# Altium schematic sheet 01.SchDoc
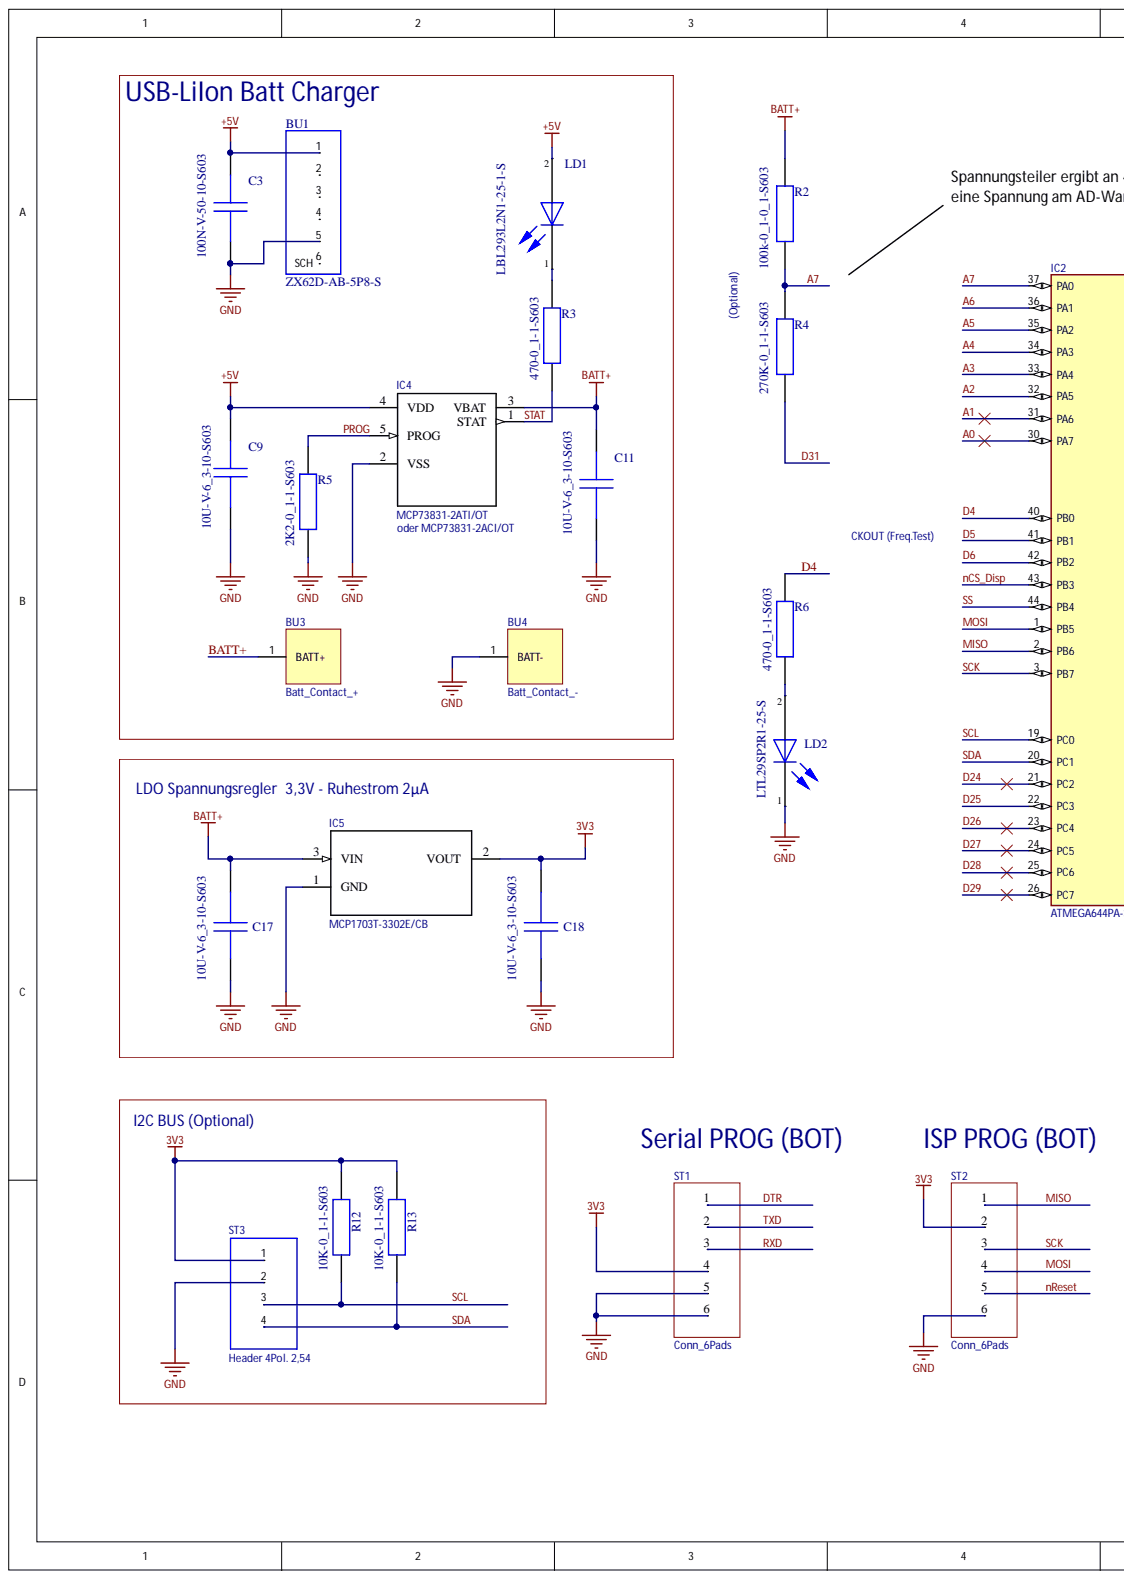
[diagram p0: 01.SchDoc, left]
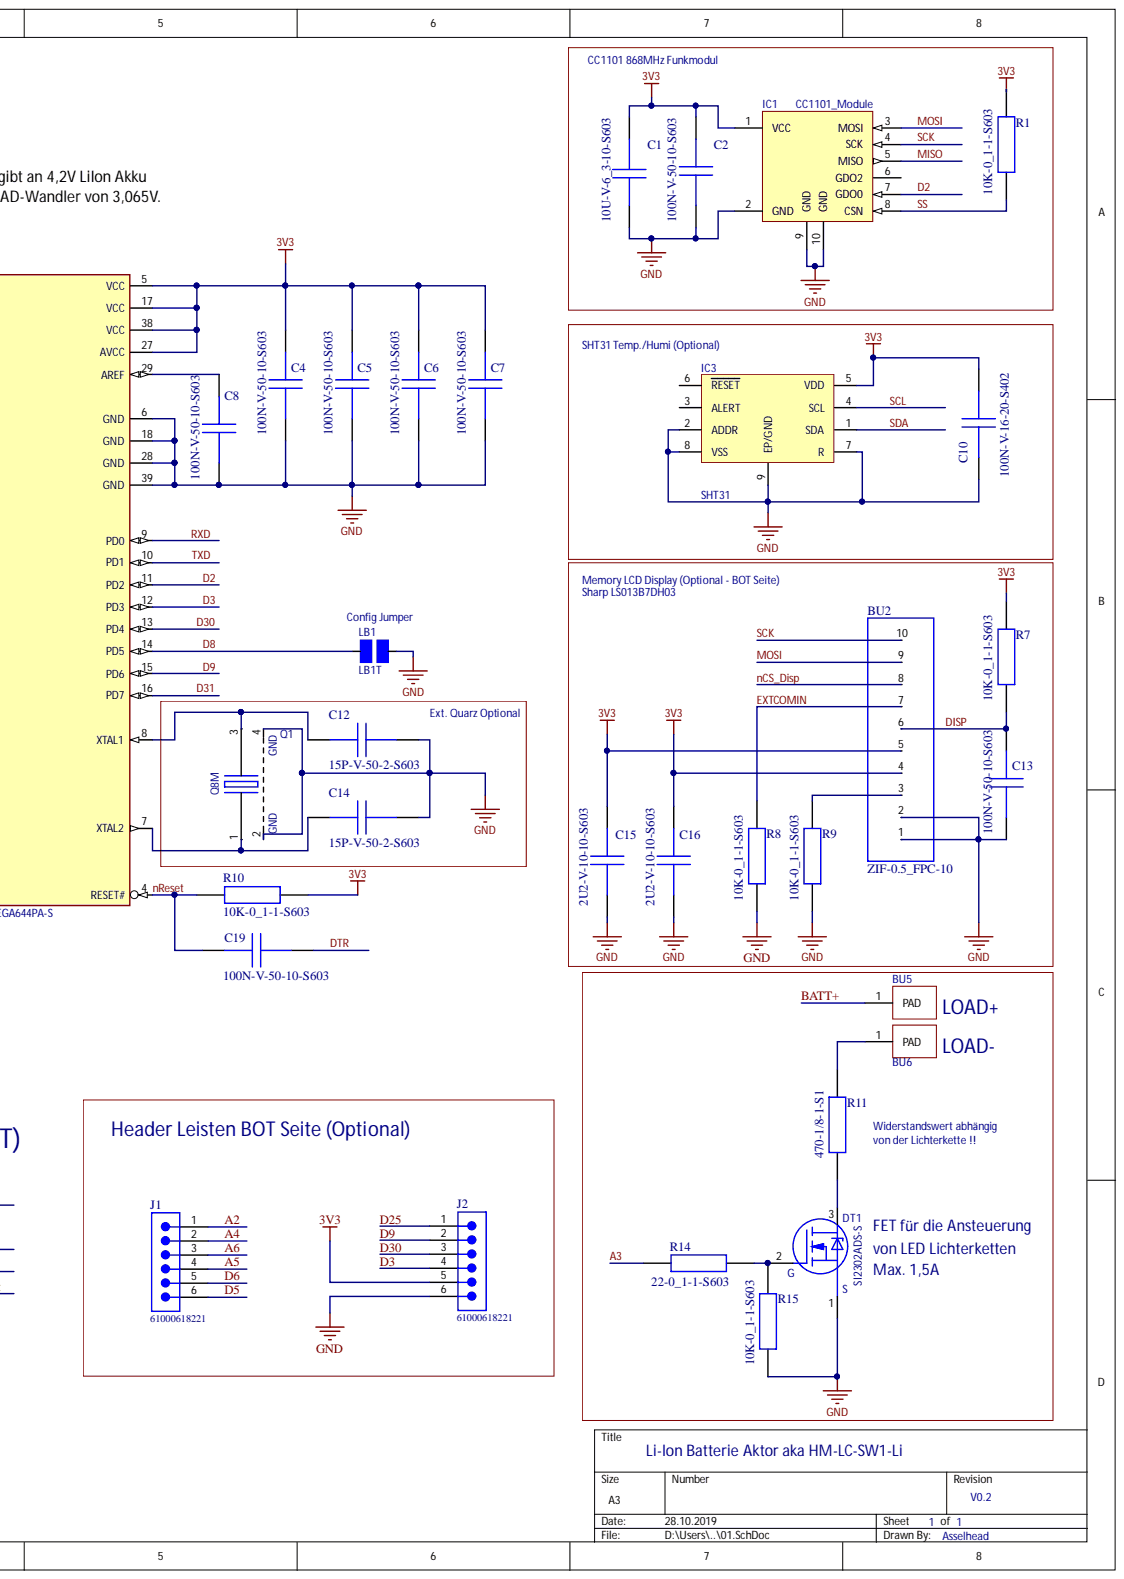
[diagram p1: 01.SchDoc, right]

## Parts
BU1  ZX62D-AB-5P8-S  [Foot5064116]
BU2  ZIF-0.5_FPC-10  [FootZIF-0.5FPC-10]
BU3  Batt_Contact_+  [Batt_Contact]
BU4  Batt_Contact_-  [Batt_Contact]
BU5  Loetpad  [Loetpad]  (value not drawn)
BU6  Loetpad  [Loetpad]  (value not drawn)
C1  10U-V-6_3-10-S603  [Foot_Capacitor_0603]
C2  100N-V-50-10-S603  [Foot_Capacitor_0603]
C3  100N-V-50-10-S603  [Foot_Capacitor_0603]
C4  100N-V-50-10-S603  [Foot_Capacitor_0603]
C5  100N-V-50-10-S603  [Foot_Capacitor_0603]
C6  100N-V-50-10-S603  [Foot_Capacitor_0603]
C7  100N-V-50-10-S603  [Foot_Capacitor_0603]
C8  100N-V-50-10-S603  [Foot_Capacitor_0603]
C9  10U-V-6_3-10-S603  [Foot_Capacitor_0603]
C10  100N-V-16-20-S402  [Foot0402R]
C11  10U-V-6_3-10-S603  [Foot_Capacitor_0603]
C12  15P-V-50-2-S603  [Foot_Capacitor_0603]
C13  100N-V-50-10-S603  [Foot_Capacitor_0603]
C14  15P-V-50-2-S603  [Foot_Capacitor_0603]
C15  2U2-V-10-10-S603  [Foot3811A]
C16  2U2-V-10-10-S603  [Foot3811A]
C17  10U-V-6_3-10-S603  [Foot_Capacitor_0603]
C18  10U-V-6_3-10-S603  [Foot_Capacitor_0603]
C19  100N-V-50-10-S603  [Foot_Capacitor_0603]
IC1  CC1101_Module  [CC1101_Module]
IC2  ATMEGA644PA-S  [Foot-TQFP44]
IC3  SHT31  [SHT31-Footprint-1]
IC4  MCP73831-2ATI/OT  [SOT23-5]
IC5  MCP1703T-3302E/CB  [SOT23-3]
J1  61000618221  [61000618221]
J2  61000618221  [61000618221]
LB1  LB1T  [Foot-LB-0603]  (no BOM)
LD1  LBL293L2N1-25-1-S  [FootLED0603_1]
LD2  LTL29SP2R1-25-S  [FootLED0603_1]
Q1  Q8M  [FootJXS32]
R1  10K-0_1-1-S603  [Foot_Resistor_0603]
R2  100k-0_1-0_1-S603  [Foot_Resistor_0603]
R3  470-0_1-1-S603  [Foot_Resistor_0603]
R4  270K-0_1-1-S603  [Foot_Resistor_0603]
R5  2K2-0_1-1-S603  [Foot_Resistor_0603]
R6  470-0_1-1-S603  [Foot_Resistor_0603]
R7  10K-0_1-1-S603  [Foot_Resistor_0603]
R8  10K-0_1-1-S603  [Foot_Resistor_0603]
R9  10K-0_1-1-S603  [Foot_Resistor_0603]
R10  10K-0_1-1-S603  [Foot_Resistor_0603]
R11  470-1/8-1-S1  [Foot4417A]
R12  10K-0_1-1-S603  [Foot_Resistor_0603]
R13  10K-0_1-1-S603  [Foot_Resistor_0603]
R14  22-0_1-1-S603  [Foot_Resistor_0603]
R15  10K-0_1-1-S603  [Foot_Resistor_0603]
ST1  Conn_6Pads  [Board_Contact6P]
ST2  Conn_6Pads  [Board_Contact6P]
ST3  Header 4Pol. 2,54  Pitch: 2,54  [Foot7331A]
T1  SI2302ADS-S  [Foot8309A]

## Nets
3V3: BU2.4 BU2.5 C1.2 C2.1 C4.1 C5.1 C6.1 C7.1 C10.1 C15.1 C16.1 C18.2 IC1.1(VCC) IC2.5(VCC) IC2.17(VCC) IC2.27(AVCC) IC2.38(VCC) IC3.5(VDD) IC5.2 J2.5 R1.2 R7.2 R10.2 R12.2 R13.2 ST1.4 ST2.2 ST3.1
+5V: BU1.1 C3.1 C9.1 IC4.4(VDD) LD1.2
GND: BU1.5 BU2.1 BU2.2 BU4.1 C1.1 C2.2 C3.2 C4.2 C5.2 C6.2 C7.2 C8.2 C9.2 C10.2 C11.2 C12.2 C13.2 C14.2 C15.2 C16.2 C17.1 C18.1 IC1.2(GND) IC1.9(GND) IC1.10(GND) IC2.6(GND) IC2.18(GND) IC2.28(GND) IC2.39(GND) IC3.2(ADDR) IC3.7(R) IC3.8(VSS) IC3.9(EP/GND) IC4.2(VSS) IC5.1 J2.6 LB1.2 LD2.1 Q1.2 Q1.4 R5.2 R8.1 R9.1 R15.1 ST1.5 ST1.6 ST2.6 ST3.2 T1.1
A0: IC2.30(PA7)
A1: IC2.31(PA6)
A2: IC2.32(PA5) J1.1
A3: IC2.33(PA4) R14.1
A4: IC2.34(PA3) J1.2
A5: IC2.35(PA2) J1.4
A6: IC2.36(PA1) J1.3
A7: IC2.37(PA0) R2.2 R4.1
BATT+: BU3.1 BU5.1 C11.1 C17.2 IC4.3(VBAT) IC5.3 R2.1
D2: IC1.7(GDO0) IC2.11(PD2)
D3: IC2.12(PD3) J2.4
D4: IC2.40(PB0) R6.2
D5: IC2.41(PB1) J1.6
D6: IC2.42(PB2) J1.5
D8: IC2.14(PD5) LB1.1
D9: IC2.15(PD6) J2.2
D24: IC2.21(PC2)
D25: IC2.22(PC3) J2.1
D26: IC2.23(PC4)
D27: IC2.24(PC5)
D28: IC2.25(PC6)
D29: IC2.26(PC7)
D30: IC2.13(PD4) J2.3
D31: IC2.16(PD7) R4.2
DISP: BU2.6 C13.1 R7.1
DTR: C19.2 ST1.1
EXTCOMIN: BU2.7 R8.2
MISO: IC1.5(MISO) IC2.2(PB6) ST2.1
MOSI: BU2.9 IC1.3(MOSI) IC2.1(PB5) ST2.4
nCS_Disp: BU2.8 IC2.43(PB3)
nReset: C19.1 IC2.4(RESET#) R10.1 ST2.5
PROG: IC4.5(PROG) R5.1
RXD: IC2.9(PD0) ST1.3
SCK: BU2.10 IC1.4(SCK) IC2.3(PB7) ST2.3
SCL: IC2.19(PC0) IC3.4(SCL) R12.1 ST3.3
SDA: IC2.20(PC1) IC3.1(SDA) R13.1 ST3.4
SS: IC1.8(CSN) IC2.44(PB4) R1.1
STAT: IC4.1(STAT) R3.1
TXD: IC2.10(PD1) ST1.2
NetBU2_3: BU2.3 R9.2
NetBU6_1: BU6.1 R11.1
NetC8_1: C8.1 IC2.29(AREF)
NetC12_1: C12.1 IC2.8(XTAL1) Q1.3
NetC14_1: C14.1 IC2.7(XTAL2) Q1.1
NetLD1_1: LD1.1 R3.2
NetLD2_2: LD2.2 R6.1
NetR11_2: R11.2 T1.3
NetR14_2: R14.2 R15.2 T1.2

Not connected: BU1 2 3 4 6; IC1 6(GDO2); IC3 3(ALERT) 6(RESET)

## Library data of the parts
BU1 = CMP-CON-000785-1: MICRO AB USB BUCHSE 5 POL + SCHIRM; ArticleNumber: 50641160; MountingTech: SMT; Symbol Name: BUCHS_5pol+AB; Component Kind: Standard; ComponentLink1Description: Datasheet; ComponentLink1URL: http://cad-plotsvr.ads.local/View/SuB_Zukauf_Datenblaetter/50641160.pdf; Library Name: SuB AVault; Type: SOCKET
BU2 = CMP-CON-001003-1: BU 10-POL. ZIF HORIZ. RM 0,5mm RIGHT ANGLE TOP CONTACT SMT; ArticleNumber: 50668680; Symbol Name: BUCHS_10pol_0pin10; Component Kind: Standard; ComponentLink1Description: Datasheet; ComponentLink1URL: http://cad-plotsvr.ads.local/View/SuB_Zukauf_Datenblaetter/50668680.pdf; Library Name: SuB AVault; MountingTech: SMT; Type: SOCKET
C1 C9 C11 C17 C18 = CMP-CAP-000549-3: SMD KONDENSATOR KERAMIK-VIELSCHICHT 10uF X5R 6,3V X5R 10%; ArticleNumber: 50666710; MountingTech: SMT; Symbol Name: CAP, unpol.; Type: CERAMIC; Value: 10µF; Voltage: 6,3V; Case/Package: 0603; Component Kind: Standard; ComponentLink1Description: Datasheet; ComponentLink1URL: http://cad-plotsvr.ads.local/View/SuB_Zukauf_Datenblaetter/50666710.pdf; Library Name: SuB AVault; neg# Tolerance: 10%; Pins: 2; Tolerance: 10%
C2-C8 C13 C19 = CMP-CAP-000390-3: SMD KONDENSATOR KERAMIK-VIELSCHICHT 100NF 50V 10%; ArticleNumber: 50630370; MountingTech: SMT; Symbol Name: CAP, unpol.; Type: CERAMIC; Case/Package: 0603; Component Kind: Standard; ComponentLink1Description: Datasheet; ComponentLink1URL: http://cad-plotsvr.ads.local/View/SuB_Zukauf_Datenblaetter/50630370.pdf; Library Name: SuB AVault; neg# Tolerance: 10%; Pins: 2; Tolerance: 10%; Value: 100nF; Voltage: 50V
C10 = CMP-CAP-000356-4: SMD KONDENSATOR KERAMIK-VIELSCHICHT 100NF 16V 20%; ArticleNumber: 50626040; MountingTech: SMT; Symbol Name: CAP, unpol.; Type: CERAMIC; Case/Package: 0402; Component Kind: Standard; ComponentLink1Description: Datasheet; ComponentLink1URL: http://cad-plotsvr.ads.local/View/SuB_Zukauf_Datenblaetter/50626040.pdf; Library Name: SuB AVault; neg# Tolerance: 20%; Pins: 2; Tolerance: 20%; Value: 100nF; Voltage: 16V
C12 C14 = CMP-CAP-000385-3: SMD KONDENSATOR KERAMIK-VIELSCHICHT 15pF 50V +- 2%; ArticleNumber: 50629990; MountingTech: SMT; Symbol Name: CAP, unpol.; Type: CERAMIC; Case/Package: 0603; Component Kind: Standard; ComponentLink1Description: Datasheet; ComponentLink1URL: http://cad-plotsvr.ads.local/View/SuB_Zukauf_Datenblaetter/50629990.pdf; Library Name: SuB AVault; neg# Tolerance: 2%; Pins: 2; Tolerance: 2%; Value: 15pF; Voltage: 50V
C15 C16 = CMP-CAP-000362-1: SMD KONDENSATOR KERAMIK-VIELSCHICHT 2,2uF 10V 10%; ArticleNumber: 50627880; MountingTech: SMT; Symbol Name: CAP, unpol.; Type: CERAMIC; Value: 2U2; Case/Package: 0603; Component Kind: Standard; ComponentLink1Description: Datasheet; ComponentLink1URL: http://cad-plotsvr.ads.local/View/SuB_Zukauf_Datenblaetter/50627880.pdf; Library Name: SuB AVault; neg# Tolerance: 10%; Tolerance: 10%; Voltage: 10V
IC2 = CMP-IC-000916-1: FLASH MICROCONTROLLER; ArticleNumber: 50653560; MountingTech: SMT; Symbol Name: CONTR-ATmega644PA-S; Component Kind: Standard; ComponentLink1Description: Datasheet; ComponentLink1URL: http://cad-plotsvr.ads.local/View/SuB_Zukauf_Datenblaetter/50653560.pdf; Library Name: SuB AVault; Type: MC CONTROLLER
IC3 = CMP-IC-001461-2: Humidity and Temperature Sensor; ArticleNumber: 50671070; MountingTech: SMT; Case/Package: DFN8; Component Kind: Standard; ComponentLink1Description: Datasheet; ComponentLink1URL: http://cad-plotsvr.ads.local/View/SuB_Zukauf_Datenblaetter/50671070.pdf; Library Name: SuB AVault; Pins: 8; Type: Sensor
IC4 = MCP73831: Component Kind: Standard; Library Name: ASKSIN.SchLib
IC5 = MCP1703-3302: Component Kind: Standard; Library Name: ASKSIN.SchLib
J1 J2 = 61000618221: SMT Vertical Pin Header WR-PHD, Pitch 2.54 mm, Single Row, 6 pins; Contact Resistance: 20 mOhm; Gender: Male; Pin Number: 6; Pitch: 2.54 mm; Rated Current: 3 A; Withstanding Voltage: 500 VAC; Working Voltage: 250 VAC; Component Kind: Standard; ComponentLink1Description: Manufacturer; ComponentLink1URL: http://www.we-online.de; ComponentLink2Description: Datasheet; ComponentLink2URL: https://katalog.we-online.de/em/datasheet/6100xx18221.pdf; Library Name: ALTIUM-PHD_2_54_SMT_PIN_HEADER_6100XX18X21-rev18b.IntLib; Mounting Technology: SMT; Operating Temperature: -40 to 125 degC; Orientation: Vertical; Packaging: Tube; Part Number: 61000618221; Published Date: August 2018; Row Number: Single; Publisher: Wurth Elektronik
LB1 = CMP-GEN-000134-2: Solder Bridge; Value: 0; Library Name: SuB AVault; Pins: 0
LD1 = CMP-OPT-000144-2: LEUCHTDIODE BLAU  (SMD TOP.); ArticleNumber: 50626940; Color: Blue; MountingTech: SMT; Note: LED-bl-SMD; Symbol Name: LED; Type: STANDARD; Value: Blue; Case/Package: 0603; Component Kind: Standard; ComponentLink1Description: Datasheet; ComponentLink1URL: http://cad-plotsvr.ads.local/View/SuB_Zukauf_Datenblaetter/50626940.pdf; Library Name: SuB AVault; Pins: 2
LD2 = CMP-OPT-000143-2: LEUCHTDIODE GRÜN   (SMD TOP.); ArticleNumber: 50626900; Color: Green; MountingTech: SMT; Note: LED-gn-SMD; Symbol Name: LED; Type: STANDARD; Value: Green; Case/Package: 0603; Component Kind: Standard; ComponentLink1Description: Datasheet; ComponentLink1URL: http://cad-plotsvr.ads.local/View/SuB_Zukauf_Datenblaetter/50626900.pdf; Library Name: SuB AVault; Pins: 2
Q1 = CMP-CLK-000121-3: SMD Quarz 12 MHz ; Jauch  12,0-JXS32-12-10/15-T1-LF; ArticleNumber: 50671930; MountingTech: SMT; TempRange: -40 °C ~ + 85 °C; Tolerance: 10; Type: Crystal; Value: 12MHz; Case/Package: JXS32; Component Kind: Standard; ComponentLink1Description: Datasheet; ComponentLink1URL: http://cad-plotsvr.ads.local/View/SuB_Zukauf_Datenblaetter/50671930.pdf; Library Name: SuB AVault; Pins: 4
R1 R7-R9 R15 = CMP-RES-000600-3: WIDERSTAND 10K 0,1W 1% BAUF.0603; ArticleNumber: 50624120; MountingTech: SMT; Power: 0,1; Symbol Name: Resistor; Case/Package: 0603; Component Kind: Standard; ComponentLink1Description: Datasheet; ComponentLink1URL: http://cad-plotsvr.ads.local/View/SuB_Zukauf_Datenblaetter/50624120.pdf; Library Name: SuB AVault; neg# Tolerance: 1%; Pins: 2; Tolerance: 1%; Type: STANDARD; Value: 10k
R2 = CMP-RES-000833-5: WIDERSTAND 100k 0,1W 0,1% BAUF.0603; ArticleNumber: 50655740; MountingTech: SMT; neg# Tolerance: 0,1%; Power: 0,1; Symbol Name: Resistor; Case/Package: 0603; Component Kind: Standard; ComponentLink1Description: Datasheet; ComponentLink1URL: http://cad-plotsvr.ads.local/View/SuB_Zukauf_Datenblaetter/50655740.pdf; Library Name: SuB AVault; Pins: 2; Tolerance: 0,1%; Type: STANDARD; Value: 100k
R3 R6 = CMP-RES-000610-5: WIDERSTAND 470R 0,1W 1% BAUF.0603; ArticleNumber: 50624530; MountingTech: SMT; Power: 0,1; Symbol Name: Resistor; Value: 470; Case/Package: 0603; Component Kind: Standard; ComponentLink1Description: Datasheet; ComponentLink1URL: http://cad-plotsvr.ads.local/View/SuB_Zukauf_Datenblaetter/50624530.pdf; Library Name: SuB AVault; neg# Tolerance: 1%; Pins: 2; Tolerance: 1%; Type: STANDARD
R4 = CMP-RES-000779-5: WIDERSTAND 270K 0,1W 1% BAUF.0603; ArticleNumber: 50645360; MountingTech: SMT; Power: 0,1; Symbol Name: Resistor; Case/Package: 0603; Component Kind: Standard; ComponentLink1Description: Datasheet; ComponentLink1URL: http://cad-plotsvr.ads.local/View/SuB_Zukauf_Datenblaetter/50645360.pdf; Library Name: SuB AVault; neg# Tolerance: 1%; Pins: 2; Tolerance: 1%; Type: STANDARD; Value: 270k
R5 = CMP-RES-000613-5: WIDERSTAND 2K2 0,1W 1% BAUF.0603; ArticleNumber: 50624560; MountingTech: SMT; Power: 0,1; Symbol Name: Resistor; Value: 2,2k; Case/Package: 0603; Component Kind: Standard; ComponentLink1Description: Datasheet; ComponentLink1URL: http://cad-plotsvr.ads.local/View/SuB_Zukauf_Datenblaetter/50624560.pdf; Library Name: SuB AVault; neg# Tolerance: 1%; Pins: 2; Tolerance: 1%; Type: STANDARD
R10 R12 R13 = CMP-RES-000600-5: WIDERSTAND 10K 0,1W 1% BAUF.0603; ArticleNumber: 50624120; MountingTech: SMT; Power: 0,1; Symbol Name: Resistor; Case/Package: 0603; Component Kind: Standard; ComponentLink1Description: Datasheet; ComponentLink1URL: http://cad-plotsvr.ads.local/View/SuB_Zukauf_Datenblaetter/50624120.pdf; Library Name: SuB AVault; neg# Tolerance: 1%; Pins: 2; Tolerance: 1%; Type: STANDARD; Value: 10k
R11 = CMP-RES-000426-3: WIDERSTAND 470R 1/8W 1% BAUF.805; ArticleNumber: 50602160; MountingTech: SMT; Power: 1/8; Symbol Name: Resistor; Value: 470; Case/Package: 0805; Component Kind: Standard; ComponentLink1Description: Datasheet; ComponentLink1URL: http://cad-plotsvr.ads.local/View/SuB_Zukauf_Datenblaetter/50602160.pdf; Library Name: SuB AVault; neg# Tolerance: 1%; Pins: 2; Tolerance: 1%; Type: STANDARD
R14 = CMP-RES-000601-5: WIDERSTAND 22R 0,1W 1% BAUF.0603; ArticleNumber: 50624280; MountingTech: SMT; Power: 0,1; Symbol Name: Resistor; Value: 22; Case/Package: 0603; Component Kind: Standard; ComponentLink1Description: Datasheet; ComponentLink1URL: http://cad-plotsvr.ads.local/View/SuB_Zukauf_Datenblaetter/50624280.pdf; Library Name: SuB AVault; neg# Tolerance: 1%; Pins: 2; Tolerance: 1%; Type: STANDARD
ST3 = CMP-CON-000014-2: Header gerade 4 Pin von 5054455; ArticleNumber: 03268580; MountingTech: THT; Note: St1rger4P5054455; Rows: 1; Symbol Name: ST-4; Component Kind: Standard; ComponentLink1Description: Datasheet; ComponentLink1URL: http://cad-plotsvr.ads.local/View/SuB_Zukauf_Datenblaetter/03268580.pdf; Library Name: SuB AVault; Pins: 4; Type: PLUG STRAIGHT
T1 = CMP-TRS-000085-3: N-CHANNEL MOSFET Si2302ADS (SMD); ArticleNumber: 50612780; I-Drain: 2,4A; MountingTech: SMT; RDSON: 85m; Symbol Name: FET_N_1; TempRange: -55°C - 150°C; Type: FET N-CHANNEL; VDS: 20V; VGS: 4,5V; VGS(th): 950mV; Case/Package: SOT23; Component Kind: Standard; ComponentLink1Description: Datasheet; ComponentLink1URL: http://cad-plotsvr.ads.local/View/SuB_Zukauf_Datenblaetter/50612780.pdf; Library Name: SuB AVault; Pins: 3
BU3 = Batt_Contact_+: Component Kind: Standard; Library Name: ASKSIN.SchLib
BU4 = Batt_Contact_-: Component Kind: Standard; Library Name: ASKSIN.SchLib
BU5 BU6 = Loetpad: Component Kind: Standard; Library Name: ASKSIN.SchLib
IC1 = CC1101_Module: Component Kind: Standard; Library Name: ASKSIN.SchLib
ST1 ST2 = Conn_6Pads: Component Kind: Standard; Library Name: ASKSIN.SchLib
